# Altium schematic sheet HEATER.SchDoc
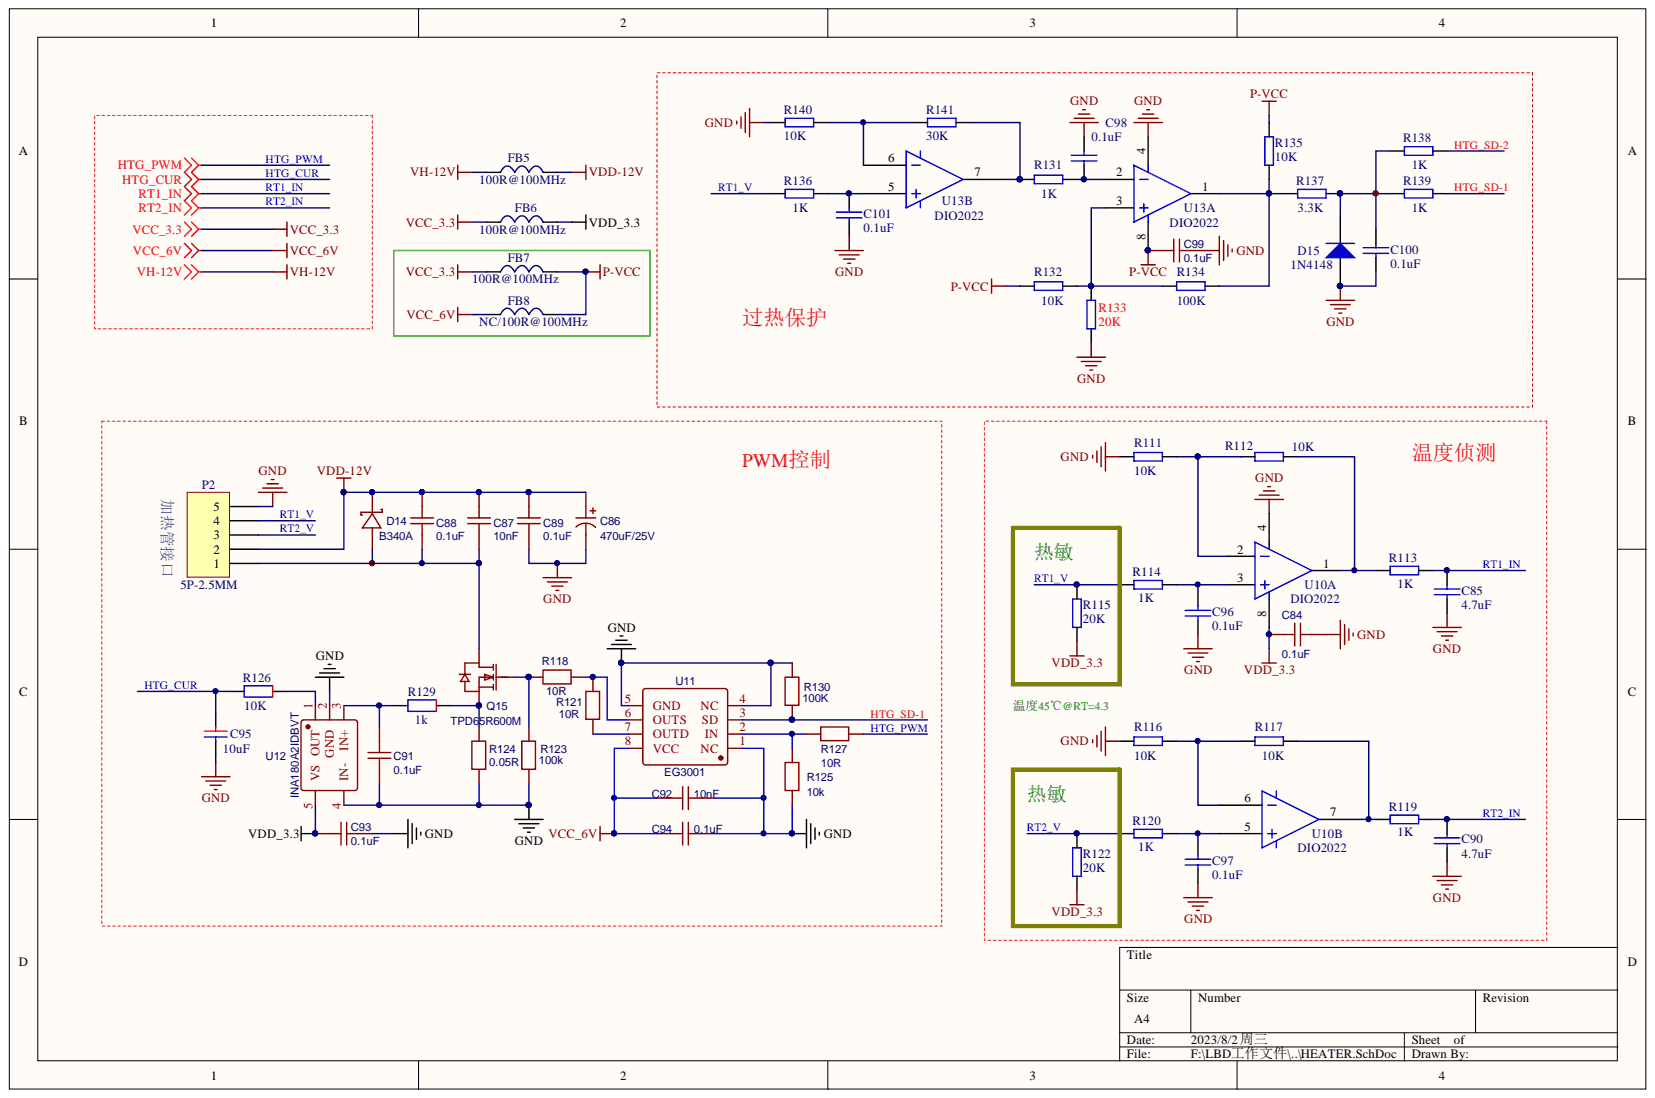
[diagram p0: HEATER.SchDoc]

## Parts
C84  0.1uF  [C0805]
C85  4.7uF  [C0402]
C86  470uF/25V  [RTV-10]
C87  10nF  [C0603]
C88  0.1uF  [C0603]
C89  0.1uF  [C0603]
C90  4.7uF  [C0402]
C91  0.1uF  [C0603]
C92  10nF  [C0402]
C93  0.1uF  [C0402]
C94  0.1uF  [C0402]
C95  10uF  [C0805]
C96  0.1uF  [C0402]
C97  0.1uF  [C0402]
C98  0.1uF  [C0402]
C99  0.1uF  [C0402]
C100  0.1uF  [C0402]
C101  0.1uF  [C0402]
D14  B340A  [DO-214AA]
D15  1N4148  [DO_214AA]
FB5  100R@100MHz  [L1812]
FB6  100R@100MHz  [L0805]
FB7  100R@100MHz  [L0805]
FB8  NC/100R@100MHz  [L0805]
P2  5P-2.5MM  [CON-5-2.5MM]
Q15  TPD65R600M  [TO-252]
R111  10K  [R0402]
R112  10K  [R0402]
R113  1K  [R0402]
R114  1K  [R0603]
R115  20K  [R0603]
R116  10K  [R0402]
R117  10K  [R0402]
R118  10R  [R0402]
R119  1K  [R0402]
R120  1K  [R0603]
R121  10R  [R0402]
R122  20K  [R0603]
R123  100k  [R0402]
R124  0.05R  [R2512]
R125  10k  [R0402]
R126  10K  [R0402]
R127  10R  [R0402]
R129  1k  [R0603]
R130  100K  [R0402]
R131  1K  [R0402]
R132  10K  [R0402]
R133  20K  [R0402]
R134  100K  [R0402]
R135  10K  [R0402]
R136  1K  [R0402]
R137  3.3K  [R0402]
R138  1K  [R0402]
R139  1K  [R0402]
R140  10K  [R0402]
R141  30K  [R0402]
U10  DIO2022  [msop8]  (parts A,B)
U11  EG3001  [SOP-8]
U12  INA180A2IDBVT  [SOT-23-5_L3.0-W1.5-P0.80-LS3.0-BL]
U13  DIO2022  [msop8]  (parts A,B)

## Nets
GND: C84.2 C85.1 C86.2 C89.1 C90.1 C91.1 C92.1 C93.1 C94.1 C95.2 C96.2 C97.2 C98.1 C99.2 C100.1 C101.1 D15.1 P2.5 R111.2 R116.2 R123.2 R124.1 R125.2 R130.1 R133.2 R140.2 U10.4 U11.1(NC) U11.4(NC) U11.5(GND) U12.2(GND) U12.4(IN-) U13.4
P-VCC: C99.1 FB7.2 FB8.2 R132.2 R135.2 U13.8
VCC_3.3: FB6.1 FB7.1
VCC_6V: C92.2 C94.2 FB8.1 U11.8(VCC)
VDD-12V: C86.1 C87.1 C88.2 C89.2 D14.2 FB5.2 P2.2
VDD_3.3: C84.1 C93.2 FB6.2 R115.1 R122.1 U10.8 U12.5(VS)
VH-12V: FB5.1
HTG_CUR: C95.1 R126.2
HTG_PWM: R127.2
HTG_SD-1: R130.2 R139.2 U11.3(SD)
HTG_SD-2: R138.2
RT1_IN: C85.2 R113.2
RT1_V: P2.4 R114.2 R115.2 R136.1
RT2_IN: C90.2 R119.2
RT2_V: P2.3 R120.2 R122.2
NetC87_2: C87.2 C88.1 D14.1 P2.1 Q15.2
NetC91_2: C91.2 R129.2 U12.3(IN+)
NetC96_1: C96.1 R114.1 U10.3
NetC97_1: C97.1 R120.1 U10.5
NetC98_2: C98.2 R131.1 U13.2
NetC100_2: C100.2 D15.2 R137.2 R138.1 R139.1
NetC101_2: C101.2 R136.2 U13.5
NetQ15_1: Q15.1 R118.1 R123.1
NetQ15_3: Q15.3 R124.2 R129.1
NetR111_1: R111.1 R112.2 U10.2
NetR112_1: R112.1 R113.1 U10.1
NetR116_1: R116.1 R117.2 U10.6
NetR117_1: R117.1 R119.1 U10.7
NetR118_2: R118.2 R121.1 U11.6(OUTS)
NetR121_2: R121.2 U11.7(OUTD)
NetR125_1: R125.1 R127.1 U11.2(IN)
NetR126_1: R126.1 U12.1(OUT)
NetR131_2: R131.2 R141.1 U13.7
NetR132_1: R132.1 R133.1 R134.2 U13.3
NetR134_1: R134.1 R135.1 R137.1 U13.1
NetR140_1: R140.1 R141.2 U13.6

## Library data of the parts
C84 C88 C89 C93 C94 C99 = 0.1u: Contributor: LCEDA_Lib; nameAlias: Value(F); Component Kind: Standard; spicePre: C; spiceSymbolName: C_0603_US
C85 C90 C96-C98 C100 C101 = CAP: Component Kind: Standard; Library Name: Integrated_Library.IntLib
C86 = 100uF/35V: BOM_Manufacturer: KNSCHA(科尼盛); BOM_Manufacturer Part: RVT100UF25V67RV0011; Contributor: LCSC; nameAlias: Capacitance; BOM_JLCPCB Part Class: Extended Part; BOM_Supplier: LCSC; BOM_Supplier Part: C2836443; Component Kind: Standard; spicePre: C; spiceSymbolName: RVT100UF25V67RV0011
C87 = 1nF: Contributor: LCEDA_Lib; nameAlias: Value(F); Component Kind: Standard; spicePre: C; spiceSymbolName: C_0603_US
C91 = 47pF: Contributor: LCEDA_Lib; nameAlias: Value(F); Component Kind: Standard; spicePre: C; spiceSymbolName: C_0603_US
C92 = 10nF: Contributor: LCEDA_Lib; nameAlias: Value(F); Component Kind: Standard; spicePre: C; spiceSymbolName: C_0603_US
C95 = Cap1: 无极性电容; Value: 100pF; Component Kind: Standard; LatestRevisionDate: 17-Jul-2002; LatestRevisionNote: Re-released for DXP Platform.; PackageDescription: Radial Cap, Thru-Hole; 2 Leads; 0.3 in Pin Spacing; PackageReference: RAD-0.3; Published: 8-Jun-2000; Simulation: CAP; Library Name: Liuqi_Part.SchLib; Publisher: Altium Limited
D14 = B340A: BOM_Manufacturer: MDD; BOM_JLCPCB Part Class: Extended Part; BOM_Manufacturer Part: B340A; BOM_Supplier: LCSC; BOM_Supplier Part: C64982; Component Kind: Standard; spicePre: D; spiceSymbolName: B340A; Library Name: np100-pcb-a04.SCHLIB
D15 = DIODE: Component Kind: Standard; Library Name: Integrated_Library.IntLib
FB5-FB8 = DIANGAN: Component Kind: Standard; Library Name: MY.SchLib
P2 = Header 5: Header, 5-Pin; Component Kind: Standard; LatestRevisionDate: 17-Jul-2002; LatestRevisionNote: Re-released for DXP Platform.; Library Name: Miscellaneous Connectors.IntLib; Publisher: Altium Limited
Q15 = TPD65R600M: BOM_Manufacturer: WUXI UNIGROUP MICRO; Contributor: LCSC; Package Display: No; BOM_JLCPCB Part Class: Extended Part; BOM_Manufacturer Part: TPD65R600M; BOM_Supplier: LCSC; BOM_Supplier Part: C596296; Component Kind: Standard; spicePre: Q; spiceSymbolName: TPD65R600M
R111-R117 R119 R120 R122 R131-R141 = RES1: Component Kind: Standard; Library Name: Integrated_Library.IntLib
R118 R121 = 10R: Contributor: LCEDA_Lib; nameAlias: Value(Ω); Component Kind: Standard; spicePre: R; spiceSymbolName: R_0603_EU
R123 = 100k: Contributor: LCEDA_Lib; nameAlias: Value(Ω); Component Kind: Standard; spicePre: R; spiceSymbolName: R_0603_EU
R124 = 0.015R: Contributor: LCEDA_Lib; nameAlias: Value(Ω); Component Kind: Standard; spicePre: R; spiceSymbolName: R_2512_EU
R125 R127 R130 = 10k: Contributor: LCEDA_Lib; nameAlias: Value(Ω); Component Kind: Standard; spicePre: R; spiceSymbolName: R_0603_EU
R126 R129 = Res2: Resistor; Component Kind: Standard; LatestRevisionDate: 17-Jul-2002; LatestRevisionNote: Re-released for DXP Platform.; PackageDescription: Axial Device, Thru-Hole; 2 Leads; 0.4 in Pin Spacing; PackageReference: AXIAL-0.4; Published: 8-Jun-2000; Simulation: RESISTOR; Library Name: Liuqi_Part.SchLib; Publisher: Altium Limited
U10 U13 = LF353: Component Kind: Standard
U11 = EG3001: BOM_Manufacturer: EG; BOM_JLCPCB Part Class: Extended Part; BOM_Manufacturer Part: EG3001; BOM_Supplier: LCSC; BOM_Supplier Part: C81708; Component Kind: Standard; spicePre: U; spiceSymbolName: EG3001
U12 = INA180A2IDBVT: BOM_Manufacturer: Texas Instruments; Contributor: LCSC; BOM_JLCPCB Part Class: Extended Part; BOM_Manufacturer Part: INA180A2IDBVT; BOM_Supplier: LCSC; BOM_Supplier Part: C116203; Component Kind: Standard; spicePre: U; spiceSymbolName: INA180A2IDBVT
